# Altium schematic sheet Controller_Board_Interface.SchDoc(Controller_Board_Interface)
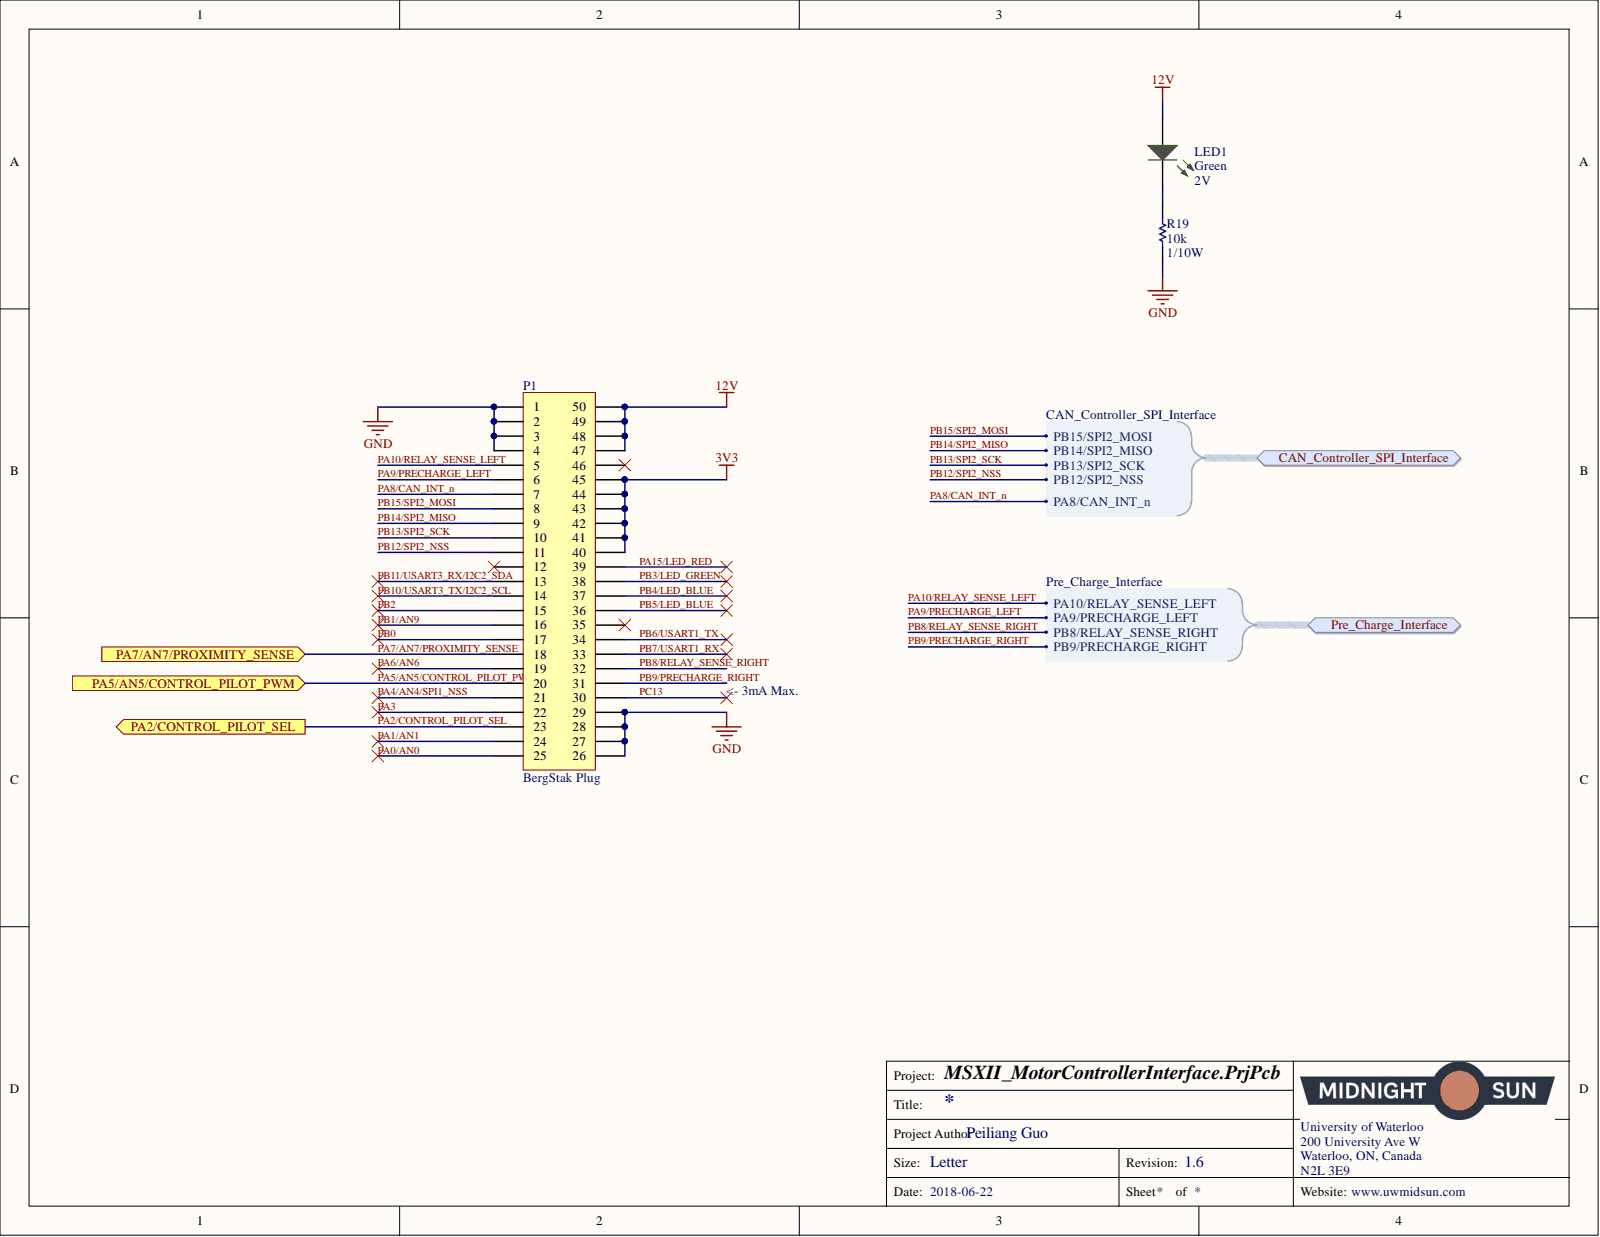
[diagram p0: Controller_Board_Interface.SchDoc]

## Parts
LED1  Voltage - Forward (Vf) (Typ): 2V  Color: Green  [LED_0603]  (hidden comment: LED GREEN CLEAR 2V 0603)
P1  Series: BergStak Plug  [CONN, Bergstak 50 Pos Plug]  (hidden comment: CONN 50POS Bergstak Plug 0.02")
R19  Resistance: 10k  Power: 1/10W  [RES, 0603]  (hidden comment: RES 10K OHM 1% 1/10W 0603)

## Ports
CAN_Controller_SPI_Interface, CAN_Controller_SPI_Interface.PA8/CAN_INT_n, CAN_Controller_SPI_Interface.PB12/SPI2_NSS, CAN_Controller_SPI_Interface.PB13/SPI2_SCK, CAN_Controller_SPI_Interface.PB14/SPI2_MISO, CAN_Controller_SPI_Interface.PB15/SPI2_MOSI, PA2/CONTROL_PILOT_SEL, PA5/AN5/CONTROL_PILOT_PWM, PA7/AN7/PROXIMITY_SENSE, Pre_Charge_Interface, Pre_Charge_Interface.PA9/PRECHARGE_LEFT, Pre_Charge_Interface.PA10/RELAY_SENSE_LEFT, Pre_Charge_Interface.PB8/RELAY_SENSE_RIGHT, Pre_Charge_Interface.PB9/PRECHARGE_RIGHT

## Nets
3V3: P1.40 P1.41 P1.42 P1.43 P1.44 P1.45
12V: LED1.1 P1.47 P1.48 P1.49 P1.50
GND: P1.1 P1.2 P1.3 P1.4 P1.26 P1.27 P1.28 P1.29 R19.2
PA0/AN0: P1.25
PA1/AN1: P1.24
PA2/CONTROL_PILOT_SEL: P1.23 ; port PA2/CONTROL_PILOT_SEL
PA3: P1.22
PA4/AN4/SPI1_NSS: P1.21
PA5/AN5/CONTROL_PILOT_PWM: P1.20 ; port PA5/AN5/CONTROL_PILOT_PWM
PA6/AN6: P1.19
PA7/AN7/PROXIMITY_SENSE: P1.18 ; port PA7/AN7/PROXIMITY_SENSE
PA8/CAN_INT_n: P1.7 ; port CAN_Controller_SPI_Interface
PA9/PRECHARGE_LEFT: P1.6 ; port Pre_Charge_Interface
PA10/RELAY_SENSE_LEFT: P1.5 ; port Pre_Charge_Interface
PA15/LED_RED: P1.39
PB0: P1.17
PB1/AN9: P1.16
PB2: P1.15
PB3/LED_GREEN: P1.38
PB4/LED_BLUE: P1.37
PB5/LED_BLUE: P1.36
PB6/USART1_TX: P1.34
PB7/USART1_RX: P1.33
PB8/RELAY_SENSE_RIGHT: P1.32 ; port Pre_Charge_Interface
PB9/PRECHARGE_RIGHT: P1.31 ; port Pre_Charge_Interface
PB10/USART3_TX/I2C2_SCL: P1.14
PB11/USART3_RX/I2C2_SDA: P1.13
PB12/SPI2_NSS: P1.11 ; port CAN_Controller_SPI_Interface
PB13/SPI2_SCK: P1.10 ; port CAN_Controller_SPI_Interface
PB14/SPI2_MISO: P1.9 ; port CAN_Controller_SPI_Interface
PB15/SPI2_MOSI: P1.8 ; port CAN_Controller_SPI_Interface
PC13: P1.30
NetLED1_2: LED1.2 R19.1

Not connected: P1 12 35 46

## Library data of the parts
LED1 = LED GREEN CLEAR 2V 0603: Green 572nm LED Indication - Discrete 2V 0603 (1608 Metric); Manufacturer Part Number: 150060VS75000; Manufacturer: Wurth Electronics Inc.; Wavelength - Dominant: 570nm; Viewing Angle: 140°; Millicandela Rating: 40mcd; Lens Style/Size: Rectangle with Flat Top, 1.10mm x 0.70mm; Lens Color: Colorless; Current - Test: 20mA; Wavelength - Peak: 572nm; Supplier Part Number 1: 732-4980-1-ND; Supplier Device Package: 0603; Supplier 1: Digi-Key; Stock 1: 9408; Size / Dimension: 1.60mm L x 0.80mm W; RoHS: RoHS Compliant; Pricing 1: 1=0.21, 100=0.1617, 500=0.13964 (CAD); Part Status: Active; Packaging: Cut Tape (CT); Package / Case: 0603 (1608 Metric); Mounting Type: Surface Mount; Lens Transparency: Clear; Height (Max): 0.80mm; Features: -; ComponentLink1URL: http://katalog.we-online.de/led/datasheet/150060VS75000.pdf; ComponentLink1Description: http://katalog.we-online.de/led/datasheet/150060VS75000.pdf; Component Kind: Standard; Category: Optoelectronics; Library Name: Schematic Diagrams.SchLib
P1 = CONN 50POS Bergstak Plug 0.02": 50 Position Connector Plug, Outer Shroud Contacts Surface Mount Gold; Manufacturer Part Number 1: 10132797-055100LF; Manufacturer 1: Amphenol FCI; Pitch: 0.020" (0.50mm); Number of Positions: 50; Mated Stacking Heights: 5mm; Supplier Part Number 1: 609-5226-1-ND; Supplier 1: Digi-Key; Part Status: Active; Packaging: Cut Tape (CT); Number of Rows: 2; Mounting Type: Surface Mount; Height Above Board: 0.169" (4.30mm); Contact Finish Thickness: 1µin (0.025µm); Contact Finish: Gold; Connector Type: Plug, Outer Shroud Contacts; ComponentLink1URL: http://portal.fciconnect.com/Comergent//fci/drawing/10132797.pdf; ComponentLink1Description: http://portal.fciconnect.com/Comergent//fci/drawing/10132797.pdf; Component Kind: Standard; Library Name: Schematic Diagrams.SchLib
R19 = RES 10K OHM 1% 1/10W 0603: RES SMD 10K OHM 1% 1/10W 0603; Manufacturer Part Number 1: RC0603FR-0710KL; Manufacturer 1: Yageo; Temperature Coefficient: ±100ppm/°C; Tolerance: ±1%; Supplier Part Number 1: 311-10.0KHRCT-ND; Supplier Device Package: 0603; Supplier 1: Digi-Key; Size / Dimension: 0.063" L x 0.031" W (1.60mm x 0.80mm); Part Status: Active; Packaging: Cut Tape (CT); Package / Case: 0603 (1608 Metric); Operating Temperature: -55°C ~ 155°C; Height - Seated (Max): 0.022" (0.55mm); Failure Rate: -; Composition: Thick Film; ComponentLink1URL: http://www.yageo.com/documents/recent/PYu-RC_Group_51_RoHS_L_7.pdf; ComponentLink1Description: http://www.yageo.com/documents/recent/PYu-RC_Group_51_RoHS_L_7.pdf; Component Kind: Standard; Library Name: Schematic Diagrams.SchLib
